# Altium schematic sheet communication.SchDoc
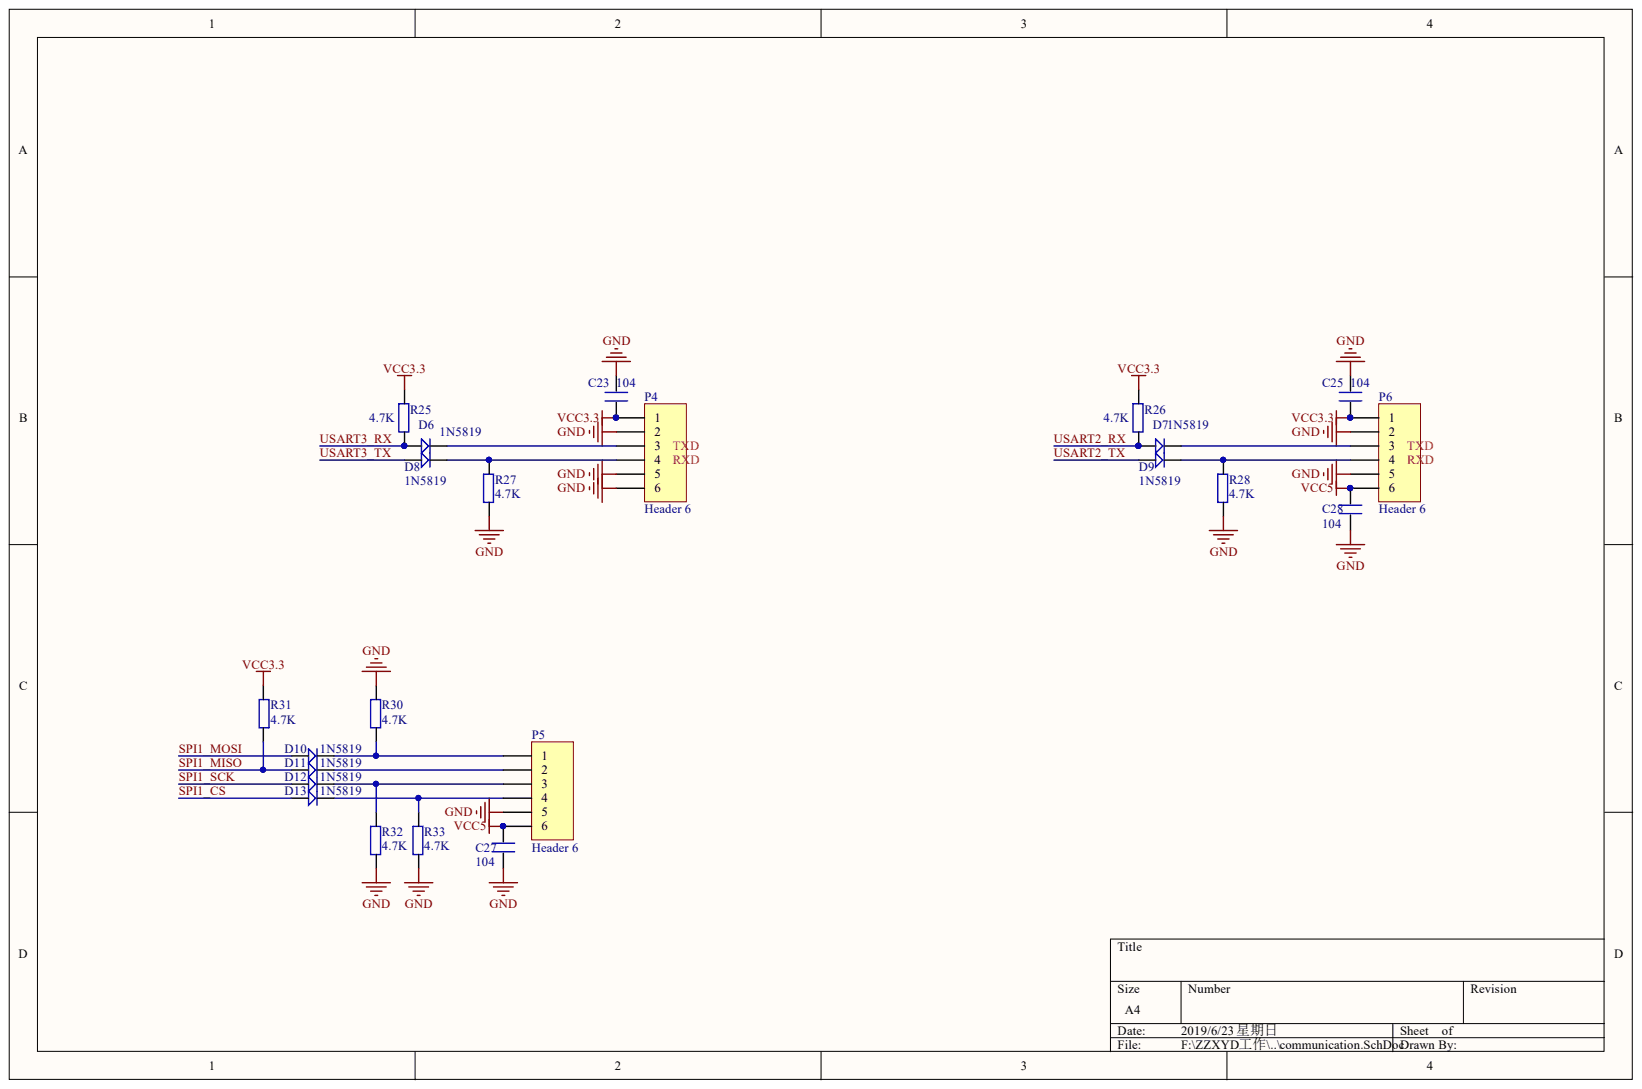
[diagram p0: communication.SchDoc]

## Parts
C23  C  value 104  [0805C]
C25  C  value 104  [0805C]
C27  C  value 104  [0805C]
C28  C  value 104  [0805C]
D6  1N5819  [1N5819]
D7  1N5819  [1N5819]
D8  1N5819  [1N5819]
D9  1N5819  [1N5819]
D10  1N5819  [1N5819]
D11  1N5819  [1N5819]
D12  1N5819  [1N5819]
D13  1N5819  [1N5819]
P4  Header 6  [HDR1X6]
P5  Header 6  [HDR1X6]
P6  Header 6  [HDR1X6]
R25  value 4.7K  [0805]  (hidden comment: RES)
R26  value 4.7K  [0805]  (hidden comment: RES)
R27  value 4.7K  [0805]  (hidden comment: RES)
R28  value 4.7K  [0805]  (hidden comment: RES)
R30  value 4.7K  [0805]  (hidden comment: RES)
R31  value 4.7K  [0805]  (hidden comment: RES)
R32  value 4.7K  [0805]  (hidden comment: RES)
R33  value 4.7K  [0805]  (hidden comment: RES)

## Nets
GND: C23.2 C25.2 C27.1 C28.1 P4.2 P4.5 P4.6 P5.5 P6.2 P6.5 R27.2 R28.2 R30.1 R32.2 R33.2
VCC3.3: C23.1 C25.1 P4.1 P6.1 R25.1 R26.1 R31.2
VCC5: C27.2 C28.2 P5.6 P6.6
SPI1_CS: D13.1
SPI1_MISO: D11.1 R31.1
SPI1_MOSI: D10.1
SPI1_SCK: D12.1
USART2_RX: D7.1 R26.2
USART2_TX: D9.1
USART3_RX: D6.1 R25.2
USART3_TX: D8.1
NetD6_2: D6.2 P4.3
NetD7_2: D7.2 P6.3
NetD8_2: D8.2 P4.4 R27.1
NetD9_2: D9.2 P6.4 R28.1
NetD10_2: D10.2 P5.1 R30.2
NetD11_2: D11.2 P5.2
NetD12_2: D12.2 P5.3 R32.1
NetD13_2: D13.2 P5.4 R33.1

## Library data of the parts
C23 C25 C27 C28 = C: CAP; Component Kind: Standard; Library Name: 主控端最小系统.SCHLIB
D6-D13 = D: Component Kind: Standard; Library Name: WarShip V3 STM32103封装库.IntLib
P4-P6 = Header 6: Header, 6-Pin; Component Kind: Standard; LatestRevisionDate: 17-Jul-2002; LatestRevisionNote: Re-released for DXP Platform.; Library Name: Miscellaneous Connectors.IntLib; Signal Integrity: Connector; Publisher: Altium Limited
R25-R28 R30-R33 = RES: Component Kind: Standard; Description: RES; Library Name: 主控端最小系统.SCHLIB
